# Altium schematic sheet [09] - AFE.SchDoc(AFE) (continued)

## Library data of the parts
C66 C67 C71-C73 C80-C82 C85 C87 C88 C90 = C-10NF-0603: CAP CER 10000PF 100V X7R 0603; Manufacturer: TDK; Manufacturer Part Number: C1608X7R2A103M080AA; .Creator: M.OZKAN; .PCBCHK: USED; Dielectric Material: Ceramic; Tolerance: 20%; Washability: OK; .SCHCHK: USED; Capacitance: 10nF; Case Code (Metric): 1608; Case/Package: 0603; Component Kind: Standard; ComponentLink1Description: http://www.mouser.com/ds/2/400/lcc_commercial_general_en-837201.pdf; ComponentLink1URL: http://www.mouser.com/ds/2/400/lcc_commercial_general_en-837201.pdf; ComponentLink2Description: http://www.farnell.com/datasheets/1484596.pdf; ComponentLink2URL: http://www.farnell.com/datasheets/1484596.pdf; ComponentLink3Description: https://www.verical.com/server-webapp/html/getDatasheet?partID=126825; ComponentLink3URL: https://www.verical.com/server-webapp/html/getDatasheet?partID=126825; ComponentLink4Description: http://www.avx.com/docs/Catalogs/cauto.pdf; ComponentLink4URL: http://www.avx.com/docs/Catalogs/cauto.pdf; ComponentLink5Description: http://www.mouser.com/ds/2/40/AutoMLCC-777028.pdf; ComponentLink5URL: http://www.mouser.com/ds/2/40/AutoMLCC-777028.pdf; Composition: Ceramic; Depth: 0.8mm; Dielectric: X7R; Features: Soft Termination; Height: 0.8mm; Lead Free: Lead Free; Length: 1.6mm; Max Operating Temperature: 125°C; Min Operating Temperature: -55°C; Mount: Surface Mount; Number of Pins: 2; Package Quantity: 1; Packaging: Tape and Reel; Pricing 1: 1=0.11, 10=0.079, 100=0.0352, 500=0.02516, 1000=0.01977 (USD); Pricing 2: 1=0.1, 10=0.066, 100=0.0293, 500=0.02092, 1000=0.01643 (USD); Pricing 3: 1=0.13, 10=0.099, 100=0.0439, 500=0.03138, 1000=0.02465, 2500=0.02241, 5000=0.02062 (USD); Radiation Hardening: No; Ratings: AEC-Q200; REACH SVHC: No SVHC; Stock 1: 40000; Stock 2: 40000; Stock 3: 89508; Supplier 1: Digi-Key; Supplier Part Number 1: 445-1305-6-ND; Termination: SMD/SMT; Thickness: 0.037inch; Voltage Rating (DC): 100V; Weight: 7.1E-05oz; Width: 0.031inch; Library Name: BARKO_SCHLIB.SchLib
C68-C70 C75 C77-C79 C83 C84 C86 C89 C91-C95 = C-100NF-0603: CAP CER 0.1UF 50V X7R 0603; Manufacturer: TDK; Manufacturer Part Number: C1608X7R1H104K080AA; .Creator: F:TALI; .PCBCHK: USED; Dielectric Material: Ceramic; Temperature Coefficient: 30ppm/°C; Tolerance: 10%; Washability: OK; .SCHCHK: USED; Capacitance: 100nF; Case Code (Metric): 1608; Case/Package: 0603; Component Kind: Standard; ComponentLink1Description: http://www.mouser.com/ds/2/400/lcc_commercial_general_en-837201.pdf; ComponentLink1URL: http://www.mouser.com/ds/2/400/lcc_commercial_general_en-837201.pdf; ComponentLink2Description: https://product.tdk.com/info/en/catalog/spec/mlccspec_commercial_general_midvoltage_en.pdf; ComponentLink2URL: https://product.tdk.com/info/en/catalog/spec/mlccspec_commercial_general_midvoltage_en.pdf; ComponentLink3Description: http://uk.rs-online.com/webdocs/13d1/0900766b813d1103.pdf; ComponentLink3URL: http://uk.rs-online.com/webdocs/13d1/0900766b813d1103.pdf; ComponentLink4Description: http://www.tdk.co.jp/tefe02/e412_c.pdf; ComponentLink4URL: http://www.tdk.co.jp/tefe02/e412_c.pdf; ComponentLink5Description: http://www.farnell.com/datasheets/2291921.pdf; ComponentLink5URL: http://www.farnell.com/datasheets/2291921.pdf; ComponentLink6Description: http://www.farnell.com/datasheets/1744655.pdf; ComponentLink6URL: http://www.farnell.com/datasheets/1744655.pdf; ComponentLink7Description: http://catalogs.avx.com/SurfaceMount.pdf; ComponentLink7URL: http://catalogs.avx.com/SurfaceMount.pdf; ComponentLink8Description: http://www.farnell.com/datasheets/630895.pdf; ComponentLink8URL: http://www.farnell.com/datasheets/630895.pdf; ComponentLink9Description: http://www.avx.com/docs/Catalogs/cx7r.pdf; ComponentLink9URL: http://www.avx.com/docs/Catalogs/cx7r.pdf; ComponentLink10Description: http://www.avx.com/docs/catalogs/cauto.pdf; ComponentLink10URL: http://www.avx.com/docs/catalogs/cauto.pdf; ComponentLink11Description: https://www.verical.com/server-webapp/html/getDatasheet?partID=98691; ComponentLink11URL: https://www.verical.com/server-webapp/html/getDatasheet?partID=98691; ComponentLink12Description: https://www.avx.com/docs/Catalogs/flexiterm.pdf; ComponentLink12URL: https://www.avx.com/docs/Catalogs/flexiterm.pdf; Composition: Ceramic; Contact Plating: Matte,Tin; Depth: 0.8mm; Dielectric: X7R; Features: Soft Termination; Height: 0.8mm; Lead Free: Lead Free; Length: 1.6mm; Max Operating Temperature: 125°C; Min Operating Temperature: -55°C; Mount: Surface Mount; Number of Pins: 2; Package Quantity: 1; Packaging: Tape and Reel; Pricing 1: 1=0.1, 10=0.057, 100=0.0254, 500=0.01812, 1000=0.01423 (USD); Pricing 2: 1=0.23, 10=0.161, 100=0.0756, 500=0.05304, 1000=0.0439 (USD); Radiation Hardening: No; REACH SVHC: No SVHC; Stock 1: 0; Stock 2: 40000; Supplier 1: Digi-Key; Supplier 2: Digi-Key; Supplier Part Number 1: 445-1314-1-ND; Supplier Part Number 2: 478-3540-6-ND; Termination: SMD/SMT; Thickness: 0.037inch; Voltage Rating: 50V; Voltage Rating (DC): 50V; Weight: 7.1E-05oz; Width: 0.8mm; Library Name: BARKO_SCHLIB.SchLib
C74 C76 = C-1UF-0603_1: CAP CER 1UF 50V X7R 0603; Manufacturer: Yageo; Manufacturer Part Number: CC0603KRX7R9BB105; .Creator: M.OZKAN; .PCBCHK: USED; Tolerance: 10%; Washability: OK; .SCHCHK: USED; Capacitance: 1uF; Case Code (Metric): 1608; Case/Package: 0603; Component Kind: Standard; ComponentLink1Description: https://www.yuden.co.jp/productdata/catalog/mlcc_all_e.pdf; ComponentLink1URL: https://www.yuden.co.jp/productdata/catalog/mlcc_all_e.pdf; ComponentLink2Description: http://datasheet.octopart.com/06035C105KAT2A-AVX-datasheet-13516023.pdf; ComponentLink2URL: http://datasheet.octopart.com/06035C105KAT2A-AVX-datasheet-13516023.pdf; Composition: Ceramic; Depth: 0.8mm; Dielectric: X7R; Halogen Free: Halogen Free; Height: 0.8mm; Lead Free: Lead Free; Length: 0.063inch; Max Operating Temperature: 125°C; Min Operating Temperature: -55°C; Mount: Surface Mount; Package Quantity: 4000; Packaging: Tape and Reel; Pricing 2: 1=0.29, 10=0.198, 100=0.1124, 500=0.07936, 1000=0.06943 (USD); Pricing 3: 1=0.73, 10=0.519, 100=0.3416, 500=0.253, 1000=0.21505 (USD); Radiation Hardening: No; Stock 1: 0; Stock 2: 0; Stock 3: 0; Supplier 1: Digi-Key; Supplier 2: Digi-Key; Supplier 3: Digi-Key; Supplier Part Number 1: 311-2082-1-ND; Supplier Part Number 2: 587-3247-6-ND; Supplier Part Number 3: 478-10073-6-ND; Termination: SMD/SMT; Thickness: 0.035inch; Voltage Rating (DC): 50V; Weight: 7.1E-05oz; Width: 0.031inch; Library Name: BARKO_SCHLIB.SchLib
FB7 FB13 = FB-330R-0603: FERRITE BEAD 330 OHM 0603 1LN; Manufacturer: Murata; Manufacturer Part Number: BLM18PG331SN1D; .Creator: M.OZKAN; .PCBCHK: USED; DC Resistance (DCR): 150mR; Material: Steel,Ferrite; Number of Circuits: 1; Shielding: Unshielded; Test Frequency: 100MHz; Tolerance: 25%; Washability: OK; .SCHCHK: USED; Case Code (Metric): 1608; Case/Package: 0603; Component Kind: Standard; ComponentLink1Description: https://datasheet.ciiva.com/6211/enfa0003-6211710.pdf?src-supplier=Digi-Key; ComponentLink1URL: https://datasheet.ciiva.com/6211/enfa0003-6211710.pdf?src-supplier=Digi-Key; Core Material: Ferrite; DC Current: 1.2A; Depth: 0.95mm; Height: 0.8mm; Impedance: 330R; Lead Free: Lead Free; Length: 1.75mm; Max Current Rating: 1.2A; Max DC Current: 1.2A; Max Operating Temperature: 125°C; Min Operating Temperature: -55°C; Number of Lines: 1; Number of Pins: 2; Package Quantity: 1; Packaging: Tape and Reel; Pricing: 1=0.1, 10=0.076, 25=0.0684, 50=0.05, 100=0.0433, 250=0.0342, 500=0.0288, 1000=0.0243 (USD); Radiation Hardening: No; REACH SVHC: No SVHC; RoHS: Yes; Stock: 106218; Supplier 1: Digi-Key; Supplier Part Number 1: 490-5222-1-ND; Termination: SMD/SMT; Width: 0.8mm; Library Name: BARKO_SCHLIB.SchLib
FB12 = FB-300R-1206: FERRITE BEAD 300 OHM 1206 1LN; Manufacturer: Wurth Electronics; Manufacturer Part Number: 742792121; .Creator: F:TALI; .PCBCHK: USED; Current Rating: 3A; DC Resistance (DCR): 60mR; Inductance: 560nh; Number of Lines: 1; Test Frequency: 110MHz; Tolerance: 25%; Washability: OK; .SCHCHK: USED; Case Code (Imperial): 1206; Case Code (Metric): 3216; Component Kind: Standard; ComponentLink1Description: http://katalog.we-online.de/pbs/datasheet/742792121.pdf; ComponentLink1URL: http://katalog.we-online.de/pbs/datasheet/742792121.pdf; ComponentLink2Description: http://www.farnell.com/datasheets/1910965.pdf; ComponentLink2URL: http://www.farnell.com/datasheets/1910965.pdf; ComponentLink3Description: http://documents.rs-components.com/techlib://itc_doclist_GB.htm?stockno=6694137; ComponentLink3URL: http://documents.rs-components.com/techlib://itc_doclist_GB.htm?stockno=6694137; ComponentLink4Description: http://www.produktinfo.conrad.com/datenblaetter/1000000-1099999/001086998-da-01-ml-WE_CBF_SMD_FERRIT_742792121_de_en.pdf; ComponentLink4URL: http://www.produktinfo.conrad.com/datenblaetter/1000000-1099999/001086998-da-01-ml-WE_CBF_SMD_FERRIT_742792121_de_en.pdf; Core Material: Ferrite; Depth: 1.6mm; Height: 1.1mm; Impedance: 300R; Length: 3.2mm; Material: Ferrite; Max Current Rating: 3A; Max DC Current: 3A; Max Operating Temperature: 125°C; Min Operating Temperature: -55°C; Mount: Surface Mount; Package Quantity: 3000; Packaging: Tape and Reel; Pricing 1: 1=0.24, 10=0.238, 50=0.219, 100=0.209, 500=0.2, 1000=0.19 (USD); REACH SVHC: Unknown; Stock 1: 17747; Supplier 1: Digi-Key; Supplier Part Number 1: 732-4654-6-ND; Termination: SMD/SMT; Weight: 0.000353oz; Width: 1.6mm; Library Name: BARKO_SCHLIB.SchLib
Q4 = Q-MMBTA06: TRANS NPN 80V 0.5A SOT23; Manufacturer: ON Semiconductor; Manufacturer Part Number: MMBTA06LT1G; .Creator: F:TALI; .PCBCHK: USED; Collector Emitter Saturation Voltage: 250mV; Current Rating: 500mA; Element Configuration: Single; Emitter Base Voltage (VEBO): 4V; Frequency: 100MHz; hFE Min: 100; Max Power Dissipation: 225mW; Number of Elements: 1; Power Dissipation: 300mW; .SCHCHK: USED; Collector Base Voltage (VCBO): 80V; Collector Emitter Breakdown Voltage: 80V; Collector Emitter Voltage (VCEO): 80V; Component Kind: Standard; ComponentLink1Description: http://www.fairchildsemi.com/ds/MM/MMBTA06.pdf; ComponentLink1URL: http://www.fairchildsemi.com/ds/MM/MMBTA06.pdf; ComponentLink2Description: http://www.farnell.com/datasheets/48137.pdf; ComponentLink2URL: http://www.farnell.com/datasheets/48137.pdf; ComponentLink3Description: http://www.farnell.com/datasheets/1852077.pdf; ComponentLink3URL: http://www.farnell.com/datasheets/1852077.pdf; ComponentLink4Description: http://www.fairchildsemi.com/datasheets/MP/MPSA06.pdf; ComponentLink4URL: http://www.fairchildsemi.com/datasheets/MP/MPSA06.pdf; ComponentLink5Description: http://www.mouser.com/ds/2/149/MMBTA06-196120.pdf; ComponentLink5URL: http://www.mouser.com/ds/2/149/MMBTA06-196120.pdf; ComponentLink6Description: https://www.verical.com/server-webapp/html/getDatasheet?partID=130058; ComponentLink6URL: https://www.verical.com/server-webapp/html/getDatasheet?partID=130058; ComponentLink7Description: https://datasheet.ciiva.com/4722/mmbta05lt1-d-101659-4722113.pdf?src-supplier=Mouser; ComponentLink7URL: https://datasheet.ciiva.com/4722/mmbta05lt1-d-101659-4722113.pdf?src-supplier=Mouser; ComponentLink8Description: https://datasheet.ciiva.com/15139/getdatasheetpartid-137838-15139444.pdf?src-supplier=Verical; ComponentLink8URL: https://datasheet.ciiva.com/15139/getdatasheetpartid-137838-15139444.pdf?src-supplier=Verical; ComponentLink9Description: https://datasheet.ciiva.com/16465/mmbta05lt1-d-16465685.pdf?src-supplier=Future+Electronics; ComponentLink9URL: https://datasheet.ciiva.com/16465/mmbta05lt1-d-16465685.pdf?src-supplier=Future+Electronics; ComponentLink10Description: https://datasheet.ciiva.com/11197/mmbta05lt1-d-11197414.pdf?src-supplier=Avnet; ComponentLink10URL: https://datasheet.ciiva.com/11197/mmbta05lt1-d-11197414.pdf?src-supplier=Avnet; ComponentLink11Description: https://datasheet.ciiva.com/11198/mmbta05lt1-d-11198678.pdf?src-supplier=Avnet; ComponentLink11URL: https://datasheet.ciiva.com/11198/mmbta05lt1-d-11198678.pdf?src-supplier=Avnet; ComponentLink12Description: https://datasheet.ciiva.com/976/mmbta05lt1-d-976473.pdf?src-supplier=Digi-Key; ComponentLink12URL: https://datasheet.ciiva.com/976/mmbta05lt1-d-976473.pdf?src-supplier=Digi-Key; ComponentLink13Description: https://datasheet.ciiva.com/976/mmbta05lt1-d-976474.pdf?src-supplier=Digi-Key; ComponentLink13URL: https://datasheet.ciiva.com/976/mmbta05lt1-d-976474.pdf?src-supplier=Digi-Key; ComponentLink14Description: https://datasheet.ciiva.com/976/mmbta05lt1-d-976475.pdf?src-supplier=Digi-Key; ComponentLink14URL: https://datasheet.ciiva.com/976/mmbta05lt1-d-976475.pdf?src-supplier=Digi-Key; ComponentLink15Description: https://datasheet.ciiva.com/7972/mmbta05lt1-d-7972078.pdf?src-supplier=Element14; ComponentLink15URL: https://datasheet.ciiva.com/7972/mmbta05lt1-d-7972078.pdf?src-supplier=Element14; ComponentLink16Description: https://datasheet.ciiva.com/16478/mmbta05lt1-d-16478574.pdf?src-supplier=Avnet+Asia; ComponentLink16URL: https://datasheet.ciiva.com/16478/mmbta05lt1-d-16478574.pdf?src-supplier=Avnet+Asia; ComponentLink17Description: https://datasheet.ciiva.com/25998/mmbta05lt1-d-25998830.pdf?src-supplier=Avnet+Europe; ComponentLink17URL: https://datasheet.ciiva.com/25998/mmbta05lt1-d-25998830.pdf?src-supplier=Avnet+Europe; Contact Plating: Tin,Matte; Gain Bandwidth Product: 100MHz; Height: 0.04inch; Lead Free: Lead Free; Length: 0.119inch; Max Breakdown Voltage: 80V; Max Collector Current: 500mA; Max Frequency: 100MHz; Max Operating Temperature: 150°C; Min Operating Temperature: -55°C; Number of Pins: 3; Package Quantity: 1; Packaging: Tape and Reel; Polarity: NPN; Pricing: 1=0.16, 10=0.15, 25=0.1424, 100=0.0627, 250=0.0602, 500=0.0492, 1000=0.0342 (USD); Radiation Hardening: No; REACH SVHC: No SVHC; RoHS: Yes; Stock: 165416; Supplier 1: Digi-Key; Supplier Part Number 1: MMBTA06LT1GOSCT-ND; Transition Frequency: 100MHz; Voltage Rating (DC): 80V; Width: 0.055inch; Library Name: BARKO_SCHLIB.SchLib
R18 R33 R35 = R-100R-0603: RES SMD 100 OHM 1% 1/8W 0603; Manufacturer: Stackpole Electronics; Manufacturer Part Number: RNCP0603FTD100R; .Creator: F:TALI; .PCBCHK: USED; Max Power Dissipation: 125mW; Number of Resistors: 1; Power Rating: 100mW; Temperature Coefficient: 100ppm/°C; Voltage Rating: 50V; Washability: OK; .SCHCHK: USED; Case Code (Metric): 1608; Case/Package: 0603; Component Kind: Standard; ComponentLink1Description: http://www.farnell.com/datasheets/1868787.pdf; ComponentLink1URL: http://www.farnell.com/datasheets/1868787.pdf; ComponentLink2Description: http://documents.rs-components.com/techlib://itc_doclist_GB.htm?stockno=5048912; ComponentLink2URL: http://documents.rs-components.com/techlib://itc_doclist_GB.htm?stockno=5048912; ComponentLink3Description: http://www.farnell.com/datasheets/1686128.pdf; ComponentLink3URL: http://www.farnell.com/datasheets/1686128.pdf; ComponentLink4Description: http://www.farnell.com/datasheets/1738695.pdf; ComponentLink4URL: http://www.farnell.com/datasheets/1738695.pdf; ComponentLink5Description: http://www.farnell.com/datasheets/443971.pdf; ComponentLink5URL: http://www.farnell.com/datasheets/443971.pdf; ComponentLink6Description: http://uk.rs-online.com/webdocs/1380/0900766b813807f7.pdf; ComponentLink6URL: http://uk.rs-online.com/webdocs/1380/0900766b813807f7.pdf; ComponentLink7Description: https://www.alliedelec.com/m/d/dd92310293d361ca5b4f9a8a31ebf2ac.pdf; ComponentLink7URL: https://www.alliedelec.com/m/d/dd92310293d361ca5b4f9a8a31ebf2ac.pdf; Composition: Thin Film; Contact Plating: Matte,Tin; Depth: 0.8mm; ELV: Compliant; Features: Anti-Sulfur,Moisture Resistant; Height: 0.02inch; Lead Free: Lead Free; Length: 0.059inch; Max Operating Temperature: 155°C; Military Standard: Not; Min Operating Temperature: -55°C; Mount: Surface Mount; Number of Pins: 2; Number of Terminations: 2; Package Quantity: 5000; Packaging: Tape and Reel; Pricing 1: 1=0.1, 10=0.067, 100=0.0273, 1000=0.01224, 2500=0.01063 (USD); Pricing 2: 1=0.1, 10=0.026, 100=0.0107, 1000=0.00478, 2500=0.00415 (USD); Radiation Hardening: No; REACH SVHC: Unknown; Resistance: 100R; Stock 1: 0; Stock 2: 30225949; Stock 3: 0; Supplier 1: Digi-Key; Supplier 2: Digi-Key; Supplier 3: Digi-Key; Supplier Part Number 1: RNCP0603FTD100RDKR-ND; Supplier Part Number 2: 311-100HRDKR-ND; Supplier Part Number 3: A106047TR-ND; Termination: SMD/SMT; Tolerance: 1%; Voltage Rating (DC): 50V; Weight: 7.1E-05oz; Width: 0.031inch; Library Name: BARKO_SCHLIB.SchLib
R19 R21 R26 R28 R31 R32 R34 R37 R38 R40 R42 = R-1K-0603: RES SMD 1K OHM 5% 1/10W 0603; Manufacturer: Yageo; Manufacturer Part Number: RC0603JR-071KL; .Creator: F:TALI; .PCBCHK: USED; Max Power Dissipation: 100mW; Resistance: 1kR; Temperature Coefficient: 100ppm/°C; Voltage Rating: 50V; Washability: OK; .SCHCHK: USED; Case Code (Metric): 1608; Case/Package: 0603; Component Kind: Standard; ComponentLink1Description: http://www.yageo.com/documents/recent/PYu-RC_Group_51_RoHS_L_2.pdf; ComponentLink1URL: http://www.yageo.com/documents/recent/PYu-RC_Group_51_RoHS_L_2.pdf; ComponentLink2Description: http://www.farnell.com/datasheets/443971.pdf; ComponentLink2URL: http://www.farnell.com/datasheets/443971.pdf; Composition: Thick Film; Contact Plating: Matte,Tin; Depth: 0.8mm; Features: Moisture Resistant; Height: 0.45mm; Lead Free: Lead Free; Length: 1.6mm; Max Operating Temperature: 155°C; Military Standard: Not; Min Operating Temperature: -55°C; Number of Pins: 2; Number of Terminations: 2; Package Quantity: 5000; Packaging: Tape and Reel; Power Rating: 100mW; Pricing 1: 1=0.09, 10=0.019, 100=0.0077, 1000=0.00346, 2500=0.00301 (EUR); Pricing 2: 1=0.1, 10=0.044, 100=0.0178, 1000=0.00798, 2500=0.00693 (USD); Pricing 3: 100=0.0125, 1000=0.0035, 5000=0.002, 15000=0.00165, 50000=0.0014 (USD); Radiation Hardening: No; REACH SVHC: Unknown; Stock 1: 16415399; Stock 2: 9; Stock 3: 0; Supplier 1: Digi-Key; Supplier 2: Digi-Key; Supplier 3: Ozdisan; Supplier Part Number 1: 311-1.0KGRCT-ND; Supplier Part Number 2: YAG1311CT-ND; Supplier Part Number 3: SMD0603-1K; Termination: SMD/SMT; Tolerance: 5%; Voltage Rating (DC): 50V; Width: 0.8mm; Library Name: BARKO_SCHLIB.SchLib
R20 R23 = R-0R-0603: RES SMD 0 OHM 1/10W JUMP 0603; Manufacturer: Yageo; Manufacturer Part Number: RC0603JR-070RL; .Creator: F:TALI; .PCBCHK: USED; Current Rating: 1A; Max Power Dissipation: 100mW; Resistance: 0mR; Temperature Coefficient: 200ppm/°C; Tolerance: 1%; Voltage Rating: 50V; Washability: OK; Working Voltage: 75V; .SCHCHK: USED; Case Code (Metric): 1608; Case/Package: 0603; Component Kind: Standard; ComponentLink1Description: http://www.farnell.com/datasheets/1738695.pdf; ComponentLink1URL: http://www.farnell.com/datasheets/1738695.pdf; ComponentLink2Description: https://www.verical.com/server-webapp/html/getDatasheet?partID=329462; ComponentLink2URL: https://www.verical.com/server-webapp/html/getDatasheet?partID=329462; ComponentLink3Description: http://www.yageo.com/documents/recent/PYu-RC_Group_51_RoHS_L_9.pdf; ComponentLink3URL: http://www.yageo.com/documents/recent/PYu-RC_Group_51_RoHS_L_9.pdf; ComponentLink4Description: http://www.farnell.com/datasheets/443971.pdf; ComponentLink4URL: http://www.farnell.com/datasheets/443971.pdf; ComponentLink5Description: http://documents.rs-components.com/techlib://itc_doclist_GB.htm?stockno=6515918; ComponentLink5URL: http://documents.rs-components.com/techlib://itc_doclist_GB.htm?stockno=6515918; ComponentLink6Description: http://www.farnell.com/datasheets/1868787.pdf; ComponentLink6URL: http://www.farnell.com/datasheets/1868787.pdf; ComponentLink7Description: http://documents.rs-components.com/techlib://itc_doclist_GB.htm?stockno=5048827; ComponentLink7URL: http://documents.rs-components.com/techlib://itc_doclist_GB.htm?stockno=5048827; ComponentLink8Description: http://industrial.panasonic.com/www-data/pdf/AOA0000/AOA0000CE1.pdf; ComponentLink8URL: http://industrial.panasonic.com/www-data/pdf/AOA0000/AOA0000CE1.pdf; ComponentLink9Description: http://www.farnell.com/datasheets/2059623.pdf; ComponentLink9URL: http://www.farnell.com/datasheets/2059623.pdf; ComponentLink10Description: http://www.jameco.com/Jameco/Products/ProdDS/1935748.pdf; ComponentLink10URL: http://www.jameco.com/Jameco/Products/ProdDS/1935748.pdf; ComponentLink11Description: http://www.farnell.com/datasheets/1678796.pdf; ComponentLink11URL: http://www.farnell.com/datasheets/1678796.pdf; ComponentLink12Description: http://www.alliedelec.com/m/d/51cd0790071e1666bdb9d00c57fcf960.pdf; ComponentLink12URL: http://www.alliedelec.com/m/d/51cd0790071e1666bdb9d00c57fcf960.pdf; Composition: Thick Film; Contact Plating: Matte,Tin; Depth: 0.8mm; Features: Moisture Resistant; Height: 0.45mm; Lead Free: Lead Free; Length: 1.6mm; Max Operating Temperature: 155°C; Military Standard: Not; Min Operating Temperature: -55°C; Mount: Surface Mount; Number of Pins: 2; Number of Terminations: 2; Package Quantity: 5000; Packaging: Tape and Reel; Power Rating: 100mW; Pricing 1: 1=0.1, 10=0.021, 25=0.0152, 50=0.0114, 100=0.0084, 250=0.00644, 500=0.00514, 1000=0.00379 (USD); Pricing 2: 1=0.1, 10=0.021, 25=0.0152, 50=0.0114, 100=0.0084, 250=0.00644, 500=0.00514, 1000=0.00379 (USD); Pricing 3: 1=0.1, 10=0.042, 25=0.0304, 50=0.0232, 100=0.0171, 250=0.01308, 500=0.01046, 1000=0.0077 (USD); Radiation Hardening: No; REACH SVHC: No SVHC; Stock 1: 54397731; Stock 2: 6104331; Stock 3: 34178498; Supplier 1: Digi-Key; Supplier 2: Digi-Key; Supplier 3: Digi-Key; Supplier Part Number 1: 311-0.0GRDKR-ND; Supplier Part Number 2: 311-0.0HRDKR-ND; Supplier Part Number 3: P0.0GDKR-ND; Termination: SMD/SMT; Voltage Rating (DC): 50V; Width: 0.8mm; Library Name: BARKO_SCHLIB.SchLib
R22 R39 R43 R45 = R-5K11-0603: RES SMD 5.11KOHM 0.1% 1/10W 0603; Manufacturer: Panasonic; Manufacturer Part Number: ERA-3AEB5111V; Max Power Dissipation: 100mW; Resistance: 5.11kR; Temperature Coefficient: 25ppm/°C; Voltage Rating: 75V; Case Code (Metric): 1608; Case/Package: 0603; Component Kind: Standard; ComponentLink1Description: https://datasheet.ciiva.com/11810/0900766b80eb1d8c-11810822.pdf?src-supplier=RSComponents; ComponentLink1URL: https://datasheet.ciiva.com/11810/0900766b80eb1d8c-11810822.pdf?src-supplier=RSComponents; ComponentLink2Description: https://datasheet.ciiva.com/29183/451892-da-01-en-widerstand-0603-era-29183907.pdf?src-supplier=Conrad; ComponentLink2URL: https://datasheet.ciiva.com/29183/451892-da-01-en-widerstand-0603-era-29183907.pdf?src-supplier=Conrad; ComponentLink3Description: https://datasheet.ciiva.com/24159/aoa0000ce26-197354-24159541.pdf?src-supplier=Mouser; ComponentLink3URL: https://datasheet.ciiva.com/24159/aoa0000ce26-197354-24159541.pdf?src-supplier=Mouser; ComponentLink4Description: https://datasheet.ciiva.com/5968/485442-5968872.pdf?src-supplier=Farnell; ComponentLink4URL: https://datasheet.ciiva.com/5968/485442-5968872.pdf?src-supplier=Farnell; ComponentLink5Description: https://datasheet.ciiva.com/5968/485442-5968873.pdf?src-supplier=Farnell; ComponentLink5URL: https://datasheet.ciiva.com/5968/485442-5968873.pdf?src-supplier=Farnell; ComponentLink6Description: https://datasheet.ciiva.com/5968/485442-5968874.pdf?src-supplier=Farnell; ComponentLink6URL: https://datasheet.ciiva.com/5968/485442-5968874.pdf?src-supplier=Farnell; ComponentLink7Description: https://datasheet.ciiva.com/9195/aoa0000ce26-9195515.pdf?src-supplier=Avnet; ComponentLink7URL: https://datasheet.ciiva.com/9195/aoa0000ce26-9195515.pdf?src-supplier=Avnet; ComponentLink8Description: https://datasheet.ciiva.com/5968/485442-5968876.pdf?src-supplier=Farnell; ComponentLink8URL: https://datasheet.ciiva.com/5968/485442-5968876.pdf?src-supplier=Farnell; ComponentLink9Description: https://datasheet.ciiva.com/7861/485442-7861692.pdf?src-supplier=Element14; ComponentLink9URL: https://datasheet.ciiva.com/7861/485442-7861692.pdf?src-supplier=Element14; ComponentLink10Description: https://datasheet.ciiva.com/7861/485442-7861693.pdf?src-supplier=Element14; ComponentLink10URL: https://datasheet.ciiva.com/7861/485442-7861693.pdf?src-supplier=Element14; Composition: Thin Film,Metal Film; Contact Plating: Tin; Depth: 0.8mm; Features: Automotive AEC-Q200; Height: 0.45mm; Lead Free: Lead Free; Length: 1.6mm; Max Operating Temperature: 155°C; Military Standard: Not; Min Operating Temperature: -55°C; Mount: Surface Mount; Number of Pins: 2; Number of Terminations: 2; Package Quantity: 5000; Packaging: Tape and Reel; Power Rating: 100mW; Pricing: 1=0.33, 10=0.275, 100=0.1076, 1000=0.045, 2500=0.0413 (USD); Radiation Hardening: No; REACH SVHC: No SVHC; RoHS: Yes; Stock: 711; Supplier 1: Digi-Key; Supplier Part Number 1: P5.11KDBCT-ND; Termination: SMD/SMT; Voltage Rating (DC): 75V; Weight: 7.1E-05oz; Width: 0.8mm; Library Name: BARKO_SCHLIB.SchLib
  R43 R45: Tolerance: 0.1%
R24 R41 = R-250R-0603: RES 250 OHM 0.01% 1/10W 0603; Manufacturer: Stackpole Electronics; Manufacturer Part Number: RNCF0603TKY250R; Max Power Dissipation: 100mW; Temperature Coefficient: 5ppm/°C; Case Code (Metric): 1608; Case/Package: 0603; Component Kind: Standard; ComponentLink1Description: https://www.seielect.com/catalog/SEI-Packaging.pdf?src-supplier=Digi-Key; ComponentLink1URL: https://www.seielect.com/catalog/SEI-Packaging.pdf?src-supplier=Digi-Key; Features: Moisture Resistant; Height: 0.022inch; Length: 0.063inch; Max Operating Temperature: 155°C; Min Operating Temperature: -55°C; Mount: Surface Mount; Number of Terminations: 2; Packaging: Tape and Reel; Pricing: 1=1.86, 10=1.65, 100=1.26 (USD); Resistance: 250R; Resistor Type: Thin Film; RoHS: Yes; Stock: 3231; Supplier 1: Digi-Key; Supplier Part Number 1: RNCF0603TKY250RCT-ND; Width: 0.031inch; Library Name: BARKO_SCHLIB.SchLib
R25 = R-27K4-0603: RES 27.4K OHM 1% 1/10W 0603; Manufacturer: Stackpole Electronics; Manufacturer Part Number: RMCF0603FT27K4; Max Power Dissipation: 100mW; Resistance: 27.4kR; Temperature Coefficient: 100ppm/°C; Case Code (Metric): 1608; Case/Package: 0603; Component Kind: Standard; ComponentLink1Description: https://datasheet.ciiva.com/26835/getdatasheetpartid-698873-26835626.pdf?src-supplier=Verical; ComponentLink1URL: https://datasheet.ciiva.com/26835/getdatasheetpartid-698873-26835626.pdf?src-supplier=Verical; Composition: Thick Film; Contact Plating: Tin,Matte; Depth: 0.8mm; Features: Automotive AEC-Q200; Height: 0.022inch; Lead Free: Lead Free; Length: 0.061inch; Max Operating Temperature: 155°C; Military Standard: Not; Min Operating Temperature: -55°C; Mount: Surface Mount; Number of Terminations: 2; Packaging: Tape and Reel; Power Rating: 100mW; Pricing: 1=0.1, 10=0.015, 100=0.0063, 1000=0.0028, 2500=0.0024 (USD); Radiation Hardening: No; RoHS: Yes; Stock: 261106; Supplier 1: Digi-Key; Supplier Part Number 1: RMCF0603FT27K4CT-ND; Width: 0.031inch; Library Name: BARKO_SCHLIB.SchLib
R27 = R-10K-0603: RES SMD 10K OHM 1% 1/10W 0603; Manufacturer: Yageo; Manufacturer Part Number: RC0603FR-0710KL; .Creator: F:TALI; .PCBCHK: USED; Max Power Dissipation: 100mW; Resistance: 10kR; Temperature Coefficient: 100ppm/°C; Voltage Rating: 75V; Voltage Rating (DC): 50V; Washability: OK; .SCHCHK: USED; Case Code (Metric): 1608; Case/Package: 0603; Component Kind: Standard; ComponentLink1Description: http://www.farnell.com/datasheets/38590.pdf; ComponentLink1URL: http://www.farnell.com/datasheets/38590.pdf; ComponentLink2Description: https://www.verical.com/server-webapp/html/getDatasheet?partID=409289; ComponentLink2URL: https://www.verical.com/server-webapp/html/getDatasheet?partID=409289; ComponentLink3Description: http://www.farnell.com/datasheets/1868787.pdf; ComponentLink3URL: http://www.farnell.com/datasheets/1868787.pdf; ComponentLink4Description: http://www.farnell.com/datasheets/443971.pdf; ComponentLink4URL: http://www.farnell.com/datasheets/443971.pdf; ComponentLink5Description: http://www.farnell.com/datasheets/1738695.pdf; ComponentLink5URL: http://www.farnell.com/datasheets/1738695.pdf; ComponentLink6Description: http://www.yageo.com/documents/recent/PYu-RC_Group_51_RoHS_L_9.pdf; ComponentLink6URL: http://www.yageo.com/documents/recent/PYu-RC_Group_51_RoHS_L_9.pdf; ComponentLink7Description: https://www.verical.com/server-webapp/html/getDatasheet?partID=327958; ComponentLink7URL: https://www.verical.com/server-webapp/html/getDatasheet?partID=327958; ComponentLink8Description: http://www.yageo.com/documents/recent/PYu-RC_Group_51_RoHS_L_2.pdf; ComponentLink8URL: http://www.yageo.com/documents/recent/PYu-RC_Group_51_RoHS_L_2.pdf; ComponentLink9Description: http://www.farnell.com/datasheets/1678796.pdf; ComponentLink9URL: http://www.farnell.com/datasheets/1678796.pdf; ComponentLink10Description: http://industrial.panasonic.com/www-cgi/jvcr13pz.cgi?E+PZ+3+AOA0002+ERJ1GNJ120C+7+WW; ComponentLink10URL: http://industrial.panasonic.com/www-cgi/jvcr13pz.cgi?E+PZ+3+AOA0002+ERJ1GNJ120C+7+WW; ComponentLink11Description: http://industrial.panasonic.com/www-data/pdf/AOA0000/AOA0000CE1.pdf; ComponentLink11URL: http://industrial.panasonic.com/www-data/pdf/AOA0000/AOA0000CE1.pdf; ComponentLink12Description: http://www.jameco.com/Jameco/Products/ProdDS/2007265.pdf; ComponentLink12URL: http://www.jameco.com/Jameco/Products/ProdDS/2007265.pdf; ComponentLink13Description: https://industrial.panasonic.com/cdbs/www-data/pdf/RDA0000/AOA0000C301.pdf; ComponentLink13URL: https://industrial.panasonic.com/cdbs/www-data/pdf/RDA0000/AOA0000C301.pdf; Composition: Thick Film; Contact Plating: Matte,Tin; Depth: 0.8mm; Features: Automotive AEC-Q200; Height: 0.45mm; Lead Free: Lead Free; Lead Pitch: 0.3mm; Length: 1.6mm; Max Operating Temperature: 155°C; Military Standard: Not; Min Operating Temperature: -55°C; Mount: Surface Mount; Number of Pins: 2; Number of Terminations: 2; Package Quantity: 1; Packaging: Tape and Reel; Power Rating: 100mW; Pricing 1: 1=0.1, 10=0.026, 100=0.0107, 1000=0.00478, 2500=0.00415 (USD); Pricing 2: 1=0.1, 10=0.022, 100=0.0088, 1000=0.00396, 2500=0.00344 (USD); Pricing 3: 1=0.1, 10=0.044, 100=0.0178, 1000=0.00798, 2500=0.00693 (USD); Radiation Hardening: No; REACH SVHC: No SVHC; Stock 1: 37479107; Stock 2: 34952003; Stock 3: 0; Supplier 1: Digi-Key; Supplier 2: Digi-Key; Supplier 3: Digi-Key; Supplier Part Number 1: 311-10.0KHRCT-ND; Supplier Part Number 2: 311-10KGRDKR-ND; Supplier Part Number 3: YAG1290DKR-ND; Termination: SMD/SMT; Tolerance: 1%; Weight: 7.1E-05oz; Width: 0.8mm; Working Voltage: 75V; Library Name: BARKO_SCHLIB.SchLib
R29 R36 = R-470R-0603: RES SMD 470 OHM 5% 1/10W 0603; Manufacturer: Yageo; Manufacturer Part Number: RC0603JR-07470RL; .Creator: F:TALI; .PCBCHK: USED; Max Power Dissipation: 100mW; Temperature Coefficient: 100ppm/°C; Voltage Rating: 50V; Washability: OK; .SCHCHK: USED; Case Code (Metric): 1608; Case/Package: 0603; Component Kind: Standard; ComponentLink1Description: http://www.yageo.com/documents/recent/PYu-RC_Group_51_RoHS_L_9.pdf; ComponentLink1URL: http://www.yageo.com/documents/recent/PYu-RC_Group_51_RoHS_L_9.pdf; ComponentLink2Description: http://www.farnell.com/datasheets/443971.pdf; ComponentLink2URL: http://www.farnell.com/datasheets/443971.pdf; ComponentLink3Description: http://www.farnell.com/datasheets/1678796.pdf; ComponentLink3URL: http://www.farnell.com/datasheets/1678796.pdf; ComponentLink4Description: http://industrial.panasonic.com/www-data/pdf/AOA0000/AOA0000CE1.pdf; ComponentLink4URL: http://industrial.panasonic.com/www-data/pdf/AOA0000/AOA0000CE1.pdf; ComponentLink5Description: https://www.alliedelec.com/m/d/51cd0790071e1666bdb9d00c57fcf960.pdf; ComponentLink5URL: https://www.alliedelec.com/m/d/51cd0790071e1666bdb9d00c57fcf960.pdf; ComponentLink6Description: https://www.verical.com/server-webapp/html/getDatasheet?partID=33087; ComponentLink6URL: https://www.verical.com/server-webapp/html/getDatasheet?partID=33087; ComponentLink7Description: http://www.farnell.com/datasheets/2059623.pdf; ComponentLink7URL: http://www.farnell.com/datasheets/2059623.pdf; ComponentLink8Description: https://www.verical.com/server-webapp/html/getDatasheet?partID=493439; ComponentLink8URL: https://www.verical.com/server-webapp/html/getDatasheet?partID=493439; Composition: Thick Film; Contact Plating: Tin; Depth: 0.8mm; Features: Moisture Resistant; Height: 0.022inch; Lead Free: Lead Free; Length: 0.063inch; Max Operating Temperature: 155°C; Military Standard: Not; Min Operating Temperature: -55°C; Mount: Surface Mount; Number of Pins: 2; Number of Terminations: 2; Package Quantity: 1; Packaging: Tape and Reel; Power Rating: 100mW; Pricing 1: 1=0.1, 10=0.022, 100=0.0088, 1000=0.00396, 2500=0.00344 (USD); Pricing 2: 1=0.1, 10=0.044, 100=0.0178, 1000=0.00798, 2500=0.00693 (USD); Pricing 3: 1=0.1, 10=0.043, 100=0.0176, 1000=0.00791, 2500=0.00686 (USD); Radiation Hardening: No; REACH SVHC: No SVHC; Resistance: 470R; Stock 1: 0; Stock 2: 2603; Stock 3: 2783393; Supplier 1: Digi-Key; Supplier 2: Digi-Key; Supplier 3: Digi-Key; Supplier Part Number 1: 311-470GRDKR-ND; Supplier Part Number 2: YAG1301DKR-ND; Supplier Part Number 3: P470GDKR-ND; Termination: SMD/SMT; Tolerance: 5%; Voltage Rating (DC): 50V; Weight: 7.1E-05oz; Width: 0.031inch; Library Name: BARKO_SCHLIB.SchLib
R30 = R-0R-0603 3 Pad: RES SMD 0 OHM 1/10W JUMP 0603; Manufacturer: Yageo; Manufacturer Part Number: RC0603JR-070RL; .Creator: F:TALI; .PCBCHK: USED; Current Rating: 1A; Max Power Dissipation: 100mW; Resistance: 0mR; Temperature Coefficient: 200ppm/°C; Tolerance: 1%; Voltage Rating: 50V; Washability: OK; Working Voltage: 75V; .SCHCHK: USED; Case Code (Metric): 1608; Case/Package: 0603; Component Kind: Standard; ComponentLink1Description: http://www.farnell.com/datasheets/1738695.pdf; ComponentLink1URL: http://www.farnell.com/datasheets/1738695.pdf; ComponentLink2Description: https://www.verical.com/server-webapp/html/getDatasheet?partID=329462; ComponentLink2URL: https://www.verical.com/server-webapp/html/getDatasheet?partID=329462; ComponentLink3Description: http://www.yageo.com/documents/recent/PYu-RC_Group_51_RoHS_L_9.pdf; ComponentLink3URL: http://www.yageo.com/documents/recent/PYu-RC_Group_51_RoHS_L_9.pdf; ComponentLink4Description: http://www.farnell.com/datasheets/443971.pdf; ComponentLink4URL: http://www.farnell.com/datasheets/443971.pdf; ComponentLink5Description: http://documents.rs-components.com/techlib://itc_doclist_GB.htm?stockno=6515918; ComponentLink5URL: http://documents.rs-components.com/techlib://itc_doclist_GB.htm?stockno=6515918; ComponentLink6Description: http://www.farnell.com/datasheets/1868787.pdf; ComponentLink6URL: http://www.farnell.com/datasheets/1868787.pdf; ComponentLink7Description: http://documents.rs-components.com/techlib://itc_doclist_GB.htm?stockno=5048827; ComponentLink7URL: http://documents.rs-components.com/techlib://itc_doclist_GB.htm?stockno=5048827; ComponentLink8Description: http://industrial.panasonic.com/www-data/pdf/AOA0000/AOA0000CE1.pdf; ComponentLink8URL: http://industrial.panasonic.com/www-data/pdf/AOA0000/AOA0000CE1.pdf; ComponentLink9Description: http://www.farnell.com/datasheets/2059623.pdf; ComponentLink9URL: http://www.farnell.com/datasheets/2059623.pdf; ComponentLink10Description: http://www.jameco.com/Jameco/Products/ProdDS/1935748.pdf; ComponentLink10URL: http://www.jameco.com/Jameco/Products/ProdDS/1935748.pdf; ComponentLink11Description: http://www.farnell.com/datasheets/1678796.pdf; ComponentLink11URL: http://www.farnell.com/datasheets/1678796.pdf; ComponentLink12Description: http://www.alliedelec.com/m/d/51cd0790071e1666bdb9d00c57fcf960.pdf; ComponentLink12URL: http://www.alliedelec.com/m/d/51cd0790071e1666bdb9d00c57fcf960.pdf; Composition: Thick Film; Contact Plating: Matte,Tin; Depth: 0.8mm; Features: Moisture Resistant; Height: 0.45mm; Lead Free: Lead Free; Length: 1.6mm; Max Operating Temperature: 155°C; Military Standard: Not; Min Operating Temperature: -55°C; Mount: Surface Mount; Number of Pins: 2; Number of Terminations: 2; Package Quantity: 5000; Packaging: Tape and Reel; Power Rating: 100mW; Pricing 1: 1=0.1, 10=0.021, 25=0.0152, 50=0.0114, 100=0.0084, 250=0.00644, 500=0.00514, 1000=0.00379 (USD); Pricing 2: 1=0.1, 10=0.021, 25=0.0152, 50=0.0114, 100=0.0084, 250=0.00644, 500=0.00514, 1000=0.00379 (USD); Pricing 3: 1=0.1, 10=0.042, 25=0.0304, 50=0.0232, 100=0.0171, 250=0.01308, 500=0.01046, 1000=0.0077 (USD); Radiation Hardening: No; REACH SVHC: No SVHC; Stock 1: 54397731; Stock 2: 6104331; Stock 3: 34178498; Supplier 1: Digi-Key; Supplier 2: Digi-Key; Supplier 3: Digi-Key; Supplier Part Number 1: 311-0.0GRDKR-ND; Supplier Part Number 2: 311-0.0HRDKR-ND; Supplier Part Number 3: P0.0GDKR-ND; Termination: SMD/SMT; Voltage Rating (DC): 50V; Width: 0.8mm; Library Name: BARKO_SCHLIB.SchLib
R44 R46 = R-22K-0603: RES SMD 22K OHM 1% 1/8W 0603; Manufacturer: Vishay Beyschlag; Manufacturer Part Number: MCT06030C2202FP500; .Creator: F:TALI; .PCBCHK: USED; Max Power Dissipation: 125mW; Resistance: 22kR; Temperature Coefficient: 50ppm/°C; Voltage Rating: 75V; Washability: OK; .SCHCHK: USED; Case Code (Metric): 1608; Case/Package: 0603; Component Kind: Standard; ComponentLink1Description: http://www.vishay.com/docs/28705/mc_pro.pdf; ComponentLink1URL: http://www.vishay.com/docs/28705/mc_pro.pdf; Composition: Thin Film; Contact Plating: Tin; Depth: 0.85mm; Height: 0.022inch; Lead Free: Lead Free; Length: 0.061inch; Max Operating Temperature: 125°C; Military Standard: Not; Min Operating Temperature: -55°C; Number of Terminations: 2; Package Quantity: 5000; Packaging: Tape and Reel; Power Rating: 125mW; Pricing 1: 1=0.18, 10=0.152, 100=0.0596, 1000=0.02495, 2500=0.02287 (USD); Radiation Hardening: No; REACH SVHC: No SVHC; Stock 1: 132469; Supplier 1: Digi-Key; Supplier Part Number 1: MCT0603-22.0K-CFDKR-ND; Tolerance: 1%; Voltage Rating (DC): 75V; Width: 0.033inch; Library Name: BARKO_SCHLIB.SchLib
R59 R60 = R-100K-0603: RES SMD 100K OHM 5% 1/10W 0603; Manufacturer: YAGEO; Manufacturer Part Number: RC0603JR-10100KL; .Creator: F:TALI; .PCBCHK: USED; Max Power Dissipation: 100mW; Number of Resistors: 1; Resistance: 100kR; Temperature Coefficient: 100ppm/°C; Voltage Rating: 50V; Washability: OK; Working Voltage: 75V; .SCHCHK: USED; Case Code (Metric): 1608; Case/Package: 0603; Component Kind: Standard; ComponentLink1Description: http://www.mouser.com/ds/2/447/RC0603-272842.pdf; ComponentLink1URL: http://www.mouser.com/ds/2/447/RC0603-272842.pdf; ComponentLink2Description: https://www.verical.com/server-webapp/html/getDatasheet?partID=326545; ComponentLink2URL: https://www.verical.com/server-webapp/html/getDatasheet?partID=326545; ComponentLink3Description: http://www.farnell.com/datasheets/2167052.pdf; ComponentLink3URL: http://www.farnell.com/datasheets/2167052.pdf; ComponentLink4Description: http://www.farnell.com/datasheets/1868787.pdf; ComponentLink4URL: http://www.farnell.com/datasheets/1868787.pdf; ComponentLink5Description: http://www.farnell.com/datasheets/1738695.pdf; ComponentLink5URL: http://www.farnell.com/datasheets/1738695.pdf; ComponentLink6Description: http://documents.rs-components.com/techlib://itc_doclist_GB.htm?stockno=5048940; ComponentLink6URL: http://documents.rs-components.com/techlib://itc_doclist_GB.htm?stockno=5048940; ComponentLink7Description: http://www.farnell.com/datasheets/443971.pdf; ComponentLink7URL: http://www.farnell.com/datasheets/443971.pdf; ComponentLink8Description: http://industrial.panasonic.com/www-data/pdf/AOA0000/AOA0000CE2.pdf; ComponentLink8URL: http://industrial.panasonic.com/www-data/pdf/AOA0000/AOA0000CE2.pdf; ComponentLink9Description: https://www.verical.com/server-webapp/html/getDatasheet?partID=247527; ComponentLink9URL: https://www.verical.com/server-webapp/html/getDatasheet?partID=247527; ComponentLink10Description: http://www.alliedelec.com/m/d/623f45ff1957d936ff56d46fd1c28cac.pdf; ComponentLink10URL: http://www.alliedelec.com/m/d/623f45ff1957d936ff56d46fd1c28cac.pdf; ComponentLink11Description: http://www.jameco.com/Jameco/Products/ProdDS/1987476.pdf; ComponentLink11URL: http://www.jameco.com/Jameco/Products/ProdDS/1987476.pdf; ComponentLink12Description: http://www1.futureelectronics.com/doc/SAMSUNG/RCSERIES.pdf; ComponentLink12URL: http://www1.futureelectronics.com/doc/SAMSUNG/RCSERIES.pdf; ComponentLink13Description: http://www.vishay.com/docs/31010/rcwpm.pdf; ComponentLink13URL: http://www.vishay.com/docs/31010/rcwpm.pdf; ComponentLink14Description: http://www.yageo.com/documents/recent/PYu-RC_Group_51_RoHS_L_9.pdf; ComponentLink14URL: http://www.yageo.com/documents/recent/PYu-RC_Group_51_RoHS_L_9.pdf; ComponentLink15Description: https://www.verical.com/server-webapp/html/getDatasheet?partID=327958; ComponentLink15URL: https://www.verical.com/server-webapp/html/getDatasheet?partID=327958; ComponentLink16Description: http://www.yageo.com/documents/recent/PYu-RC_Group_51_RoHS_L_2.pdf; ComponentLink16URL: http://www.yageo.com/documents/recent/PYu-RC_Group_51_RoHS_L_2.pdf; ComponentLink17Description: http://www.yageo.com/NewPortal/yageodocoutput?fileName=/pdf/R-Chip/PYu-RT_1-to-0.05_RoHS_L_5.pdf; ComponentLink17URL: http://www.yageo.com/NewPortal/yageodocoutput?fileName=/pdf/R-Chip/PYu-RT_1-to-0.05_RoHS_L_5.pdf; ComponentLink18Description: http://documents.rs-components.com/techlib://itc_doclist_GB.htm?stockno=6185113; ComponentLink18URL: http://documents.rs-components.com/techlib://itc_doclist_GB.htm?stockno=6185113; ComponentLink19Description: http://www.yageo.ru/pdf/RC0805.pdf; ComponentLink19URL: http://www.yageo.ru/pdf/RC0805.pdf; ComponentLink20Description: http://www.farnell.com/datasheets/38627.pdf; ComponentLink20URL: http://www.farnell.com/datasheets/38627.pdf; ComponentLink21Description: http://www.farnell.com/datasheets/1697719.pdf; ComponentLink21URL: http://www.farnell.com/datasheets/1697719.pdf; ComponentLink22Description: http://www.farnell.com/datasheets/1686128.pdf; ComponentLink22URL: http://www.farnell.com/datasheets/1686128.pdf; ComponentLink23Description: http://www.farnell.com/datasheets/444164.pdf; ComponentLink23URL: http://www.farnell.com/datasheets/444164.pdf; ComponentLink24Description: http://www.alliedelec.com/m/d/dd92310293d361ca5b4f9a8a31ebf2ac.pdf; ComponentLink24URL: http://www.alliedelec.com/m/d/dd92310293d361ca5b4f9a8a31ebf2ac.pdf; ComponentLink25Description: http://www.mouser.com/ds/2/418/NG_CD_1622827_BA-655491.pdf; ComponentLink25URL: http://www.mouser.com/ds/2/418/NG_CD_1622827_BA-655491.pdf; ComponentLink26Description: http://uk.rs-online.com/webdocs/13d1/0900766b813d116f.pdf; ComponentLink26URL: http://uk.rs-online.com/webdocs/13d1/0900766b813d116f.pdf; ComponentLink27Description: https://www.verical.com/server-webapp/html/getDatasheet?partID=359906; ComponentLink27URL: https://www.verical.com/server-webapp/html/getDatasheet?partID=359906; ComponentLink28Description: http://datasheet.octopart.com/0603SAJ0104T5E-Royal-Ohm-datasheet-17591902.pdf; ComponentLink28URL: http://datasheet.octopart.com/0603SAJ0104T5E-Royal-Ohm-datasheet-17591902.pdf; ComponentLink29Description: http://datasheet.octopart.com/0603SAJ0104T5E-Royal-Ohm-datasheet-62069544.pdf; ComponentLink29URL: http://datasheet.octopart.com/0603SAJ0104T5E-Royal-Ohm-datasheet-62069544.pdf; ComponentLink30Description: http://datasheet.octopart.com/0603SAJ0104T5E-Royal-Electronics-datasheet-17591902.pdf; ComponentLink30URL: http://datasheet.octopart.com/0603SAJ0104T5E-Royal-Electronics-datasheet-17591902.pdf; Composition: Thick Film; Contact Plating: Matte,Tin; Depth: 0.8mm; ELV: Compliant; Failure Rate: 0.01%; Features: Moisture Resistant; Height: 0.022inch; Height - Seated (Max): 0.022inch; Lead Free: Lead Free; Lead Pitch: 0.4mm; Length: 1.6mm; Max Operating Temperature: 155°C; Military Standard: Not; Min Operating Temperature: -55°C; Mount: Surface Mount; Number of Pins: 2; Number of Terminations: 2; Package Quantity: 10000; Packaging: Tape and Reel; Power Rating: 100mW; Pricing 1: 1=0.1, 10=0.022, 100=0.0088, 1000=0.00396, 2500=0.00344, 5000=0.00284 (USD); Pricing 2: 5000=0.0033, 10000=0.00287, 25000=0.00252, 50000=0.00231, 125000=0.00229 (USD); Pricing 3: 1=0.1, 10=0.026, 100=0.0104, 1000=0.00469, 2500=0.00407 (USD); Pricing 4: 1=0.1, 10=0.065, 100=0.0264, 1000=0.01186, 2500=0.01029 (USD); Pricing 5: 1=0.25, 10=0.211, 25=0.1532, 50=0.111, 100=0.0823, 250=0.06316, 500=0.04976, 1000=0.03445 (USD); Pricing 6: 1=0.1, 10=0.016, 100=0.0064, 1000=0.00289, 2500=0.0025 (USD); Pricing 8: 1=0.1, 10=0.028, 100=0.0113, 1000=0.00507, 2500=0.0044 (USD); Radiation Hardening: No; REACH SVHC: No SVHC; Stock 1: 0; Stock 2: 0; Stock 3: 219997; Stock 4: 9351077; Stock 5: 0; Stock 6: 227219; Stock 7: 0; Stock 8: 1000; Supplier 1: Digi-Key; Supplier 2: Digi-Key; Supplier 3: Digi-Key; Supplier 4: Digi-Key; Supplier 5: Digi-Key; Supplier 6: Digi-Key; Supplier 7: Ozdisan; Supplier 8: Digi-Key; Supplier Part Number 1: YAG2294DKR-ND; Supplier Part Number 2: 1276-5110-2-ND; Supplier Part Number 3: A106046DKR-ND; Supplier Part Number 4: P100KHCT-ND; Supplier Part Number 5: P20200DKR-ND; Supplier Part Number 6: RMCF0603JT100KDKR-ND; Supplier Part Number 7: SMD0603-100K; Supplier Part Number 8: 1276-5110-6-ND; Termination: SMD/SMT; Tolerance: 5%; Voltage Rating (DC): 50V; Weight: 7.1E-05oz; Width: 0.031inch; Library Name: BARKO_SCHLIB.SchLib
TP5-TP8 TP45 TP47-TP68 = MECH-TESTPOINT: .Creator: F:TALI; .PCBCHK: USED; Washability: OK; .SCHCHK: USED; Library Name: BARKO_SCHLIB.SchLib
U8 = IC-LM2904BQDRQ1: IC OPAMP GP 2 CIRCUIT 8SOIC; Manufacturer: Texas Instruments; Component Kind: Standard; ComponentLink1Description: datasheet; ComponentLink1URL: https://www.ti.com/lit/ds/symlink/lm2904-q1.pdf?HQS=TI-null-null-digikeymode-df-pf-null-wwe&ts=1602145041041; Library Name: SCHLIB.SchLib; Manufacturer Part Number: LM2904BQDRQ1; Pricing: 1=0.44, 10=0.375, 25=0.35, 100=0.26, 250=0.22, 500=0.21, 1000=0.155 (USD); RoHS: No; Stock: 1587; Supplier 1: Digi-Key; Supplier Part Number 1: 296-LM2904BQDRQ1CT-ND
U9 = IC-AD7124-8BCPZ-RL7: IC ADC 24BIT SIGMA-DELTA 32LFCSP; Manufacturer: Analog Devices; Common Mode Rejection Ratio: 76dB; Dual Supply Voltage: 1.8V; Input Capacitance: 5.5pF; Input Offset Voltage (Vos): 1mV; Interface: SPI; Max Supply Voltage: 3.6V; Min Supply Voltage: 2.7V; Number of A/D Converters: 1; Number of Bits: 24; Operating Supply Current: 5.6mA; Polarity: Bipolar,Unipolar; Power Supply Rejection Ratio (PSRR): 95dB; Quiescent Current: 2.8mA; Resolution: 24b; Sampling Rate: 19.2KS/s; Settling Time: 1us; Slew Rate: 20V/µs; Supply Type: Analog,Digital; Component Kind: Standard; ComponentLink1Description: http://www.mouser.com/ds/2/609/AD7124-8-586740.pdf?src-supplier=Mouser; ComponentLink1URL: http://www.mouser.com/ds/2/609/AD7124-8-586740.pdf?src-supplier=Mouser; ComponentLink2Description: http://www.analog.com/media/en/technical-documentation/data-sheets/AD7124-8.pdf?src-supplier=Digi-Key; ComponentLink2URL: http://www.analog.com/media/en/technical-documentation/data-sheets/AD7124-8.pdf?src-supplier=Digi-Key; ComponentLink3Description: http://www.analog.com/media/en/technical-documentation/data-sheets/AD7124-8.pdf?src-supplier=Avnet; ComponentLink3URL: http://www.analog.com/media/en/technical-documentation/data-sheets/AD7124-8.pdf?src-supplier=Avnet; ComponentLink4Description: https://www.analog.com/media/en/technical-documentation/data-sheets/ad7124-8.pdf?src-supplier=Digi-Key; ComponentLink4URL: https://www.analog.com/media/en/technical-documentation/data-sheets/ad7124-8.pdf?src-supplier=Digi-Key; ComponentLink5Description: http://www.farnell.com/datasheets/2251043.pdf?src-supplier=Farnell; ComponentLink5URL: http://www.farnell.com/datasheets/2251043.pdf?src-supplier=Farnell; ComponentLink6Description: http://www.farnell.com/datasheets/2081834.pdf?src-supplier=Element14; ComponentLink6URL: http://www.farnell.com/datasheets/2081834.pdf?src-supplier=Element14; Height: 0.75mm; Lead Free: Contains Lead; Length: 5.1mm; Manufacturer Part Number: AD7124-8BCPZ; Max Operating Temperature: 125°C; Min Operating Temperature: -55°C; Mount: Surface Mount; Number of Pins: 32; Packaging: Tray; Pricing: 1=11.73, 10=10.6, 25=10.1064, 100=8.381, 250=7.6415, 500=7.1485 (USD); REACH SVHC: No SVHC; RoHS: Yes; Stock: 2540; Supplier 1: Digi-Key; Supplier Part Number 1: AD7124-8BCPZ-ND; Width: 5.1mm; Library Name: BARKO_SCHLIB.SchLib
Y2 = OSC-SG-210STF 2.4576ML: XTAL OSC XO 2.4576MHZ CMOS SMD; Manufacturer: Epson; Frequency Stability: 50ppm; Max Supply Current: 1.8mA; Case/Package: SMD/SMT; Component Kind: Standard; ComponentLink1Description: https://datasheet.ciiva.com/29629/029-sg-210stf-e147-29629205.pdf?src-supplier=Digi-Key; ComponentLink1URL: https://datasheet.ciiva.com/29629/029-sg-210stf-e147-29629205.pdf?src-supplier=Digi-Key; Frequency: 2.4576MHz; Height: 0.035inch; Length: 0.098inch; Library Name: SCHLIB.SchLib; Manufacturer Part Number: SG-210STF 2.4576ML; Max Operating Temperature: 85°C; Min Operating Temperature: -40°C; Mount: Surface Mount; Packaging: Cut Strip; Pricing: 1=1.22, 10=1.081, 50=1.0106, 100=0.893 (USD); RoHS: Yes; Stock: 214; Supplier 1: Digi-Key; Supplier Part Number 1: SER3866-ND; Width: 0.079inch
